# Altium schematic sheet c2020_schematic.SchDoc(c2020_schematic)
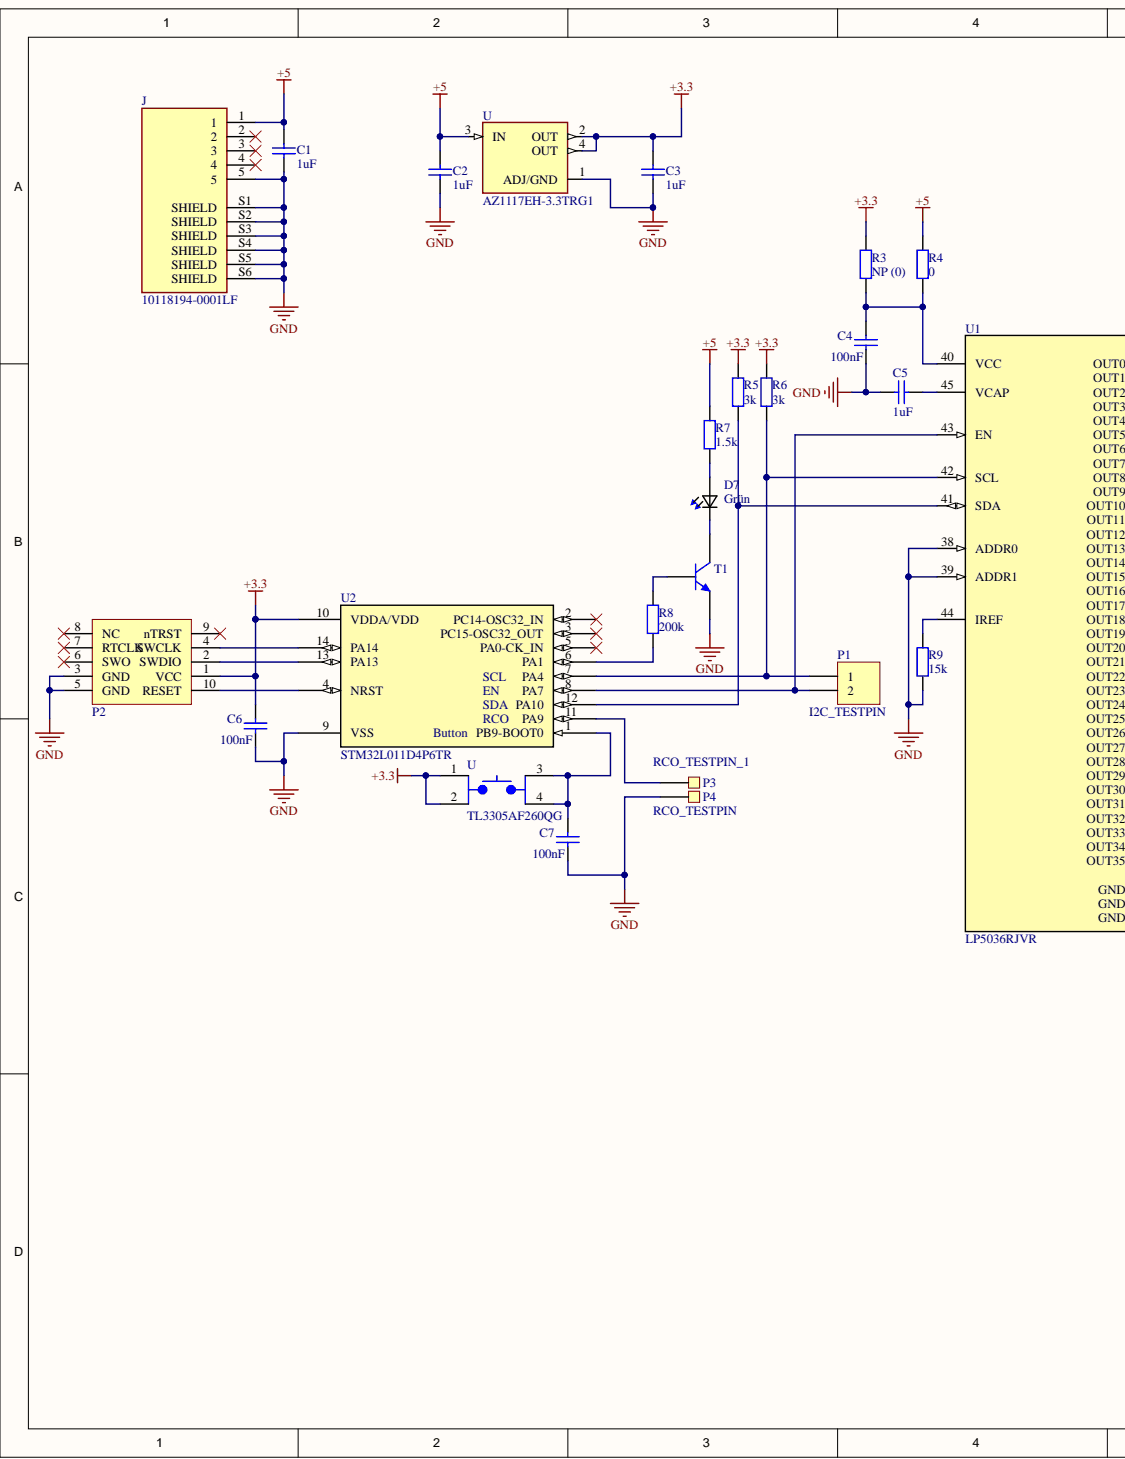
[diagram p0: c2020_schematic.SchDoc, left]
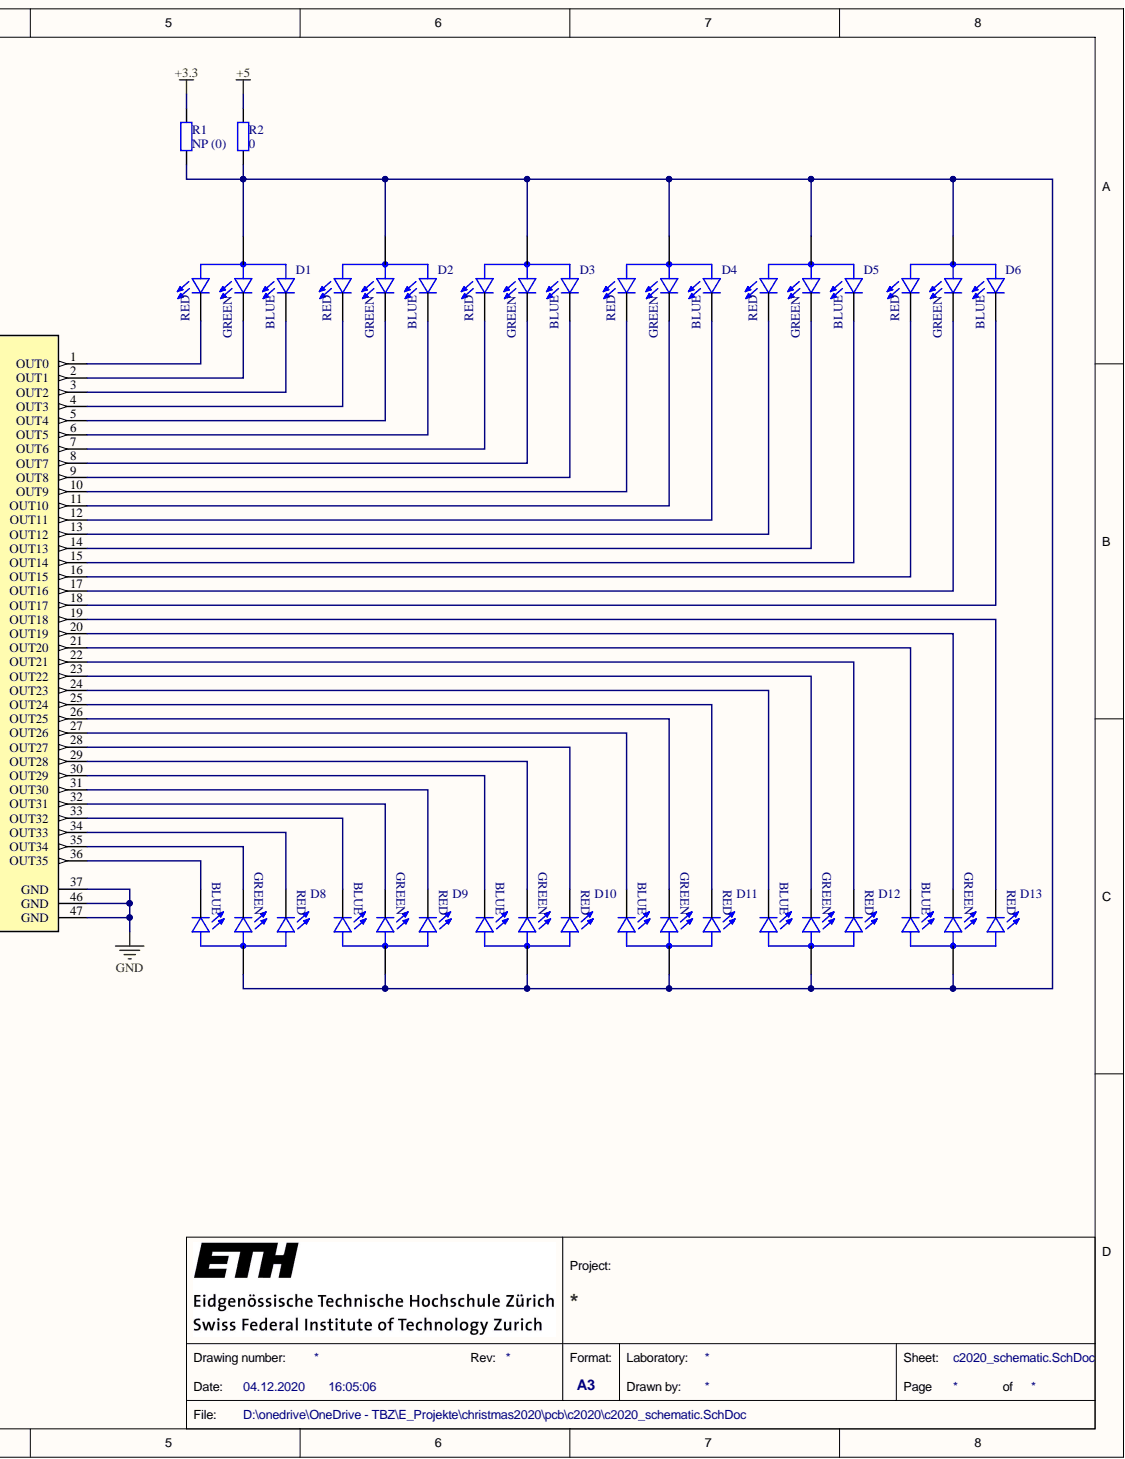
[diagram p1: c2020_schematic.SchDoc, right]

## Parts
C1  1uF  [CAP_0603i_1608m -N]
C2  1uF  [CAP_0603i_1608m -N]
C3  1uF  [CAP_0603i_1608m -N]
C4  100nF  [CAP_0402i_1005m -N]
C5  1uF  [CAP_0603i_1608m -N]
C6  100nF  [CAP_0402i_1005m -N]
C7  100nF  [CAP_0402i_1005m -N]
D1  156120M173000  [LED_156120M173000]  (value not drawn)
D2  156120M173000  [LED_156120M173000]  (value not drawn)
D3  156120M173000  [LED_156120M173000]  (value not drawn)
D4  156120M173000  [LED_156120M173000]  (value not drawn)
D5  156120M173000  [LED_156120M173000]  (value not drawn)
D6  156120M173000  [LED_156120M173000]  (value not drawn)
D7  Grün  [*0603]
D8  156120M173000  [LED_156120M173000]  (value not drawn)
D9  156120M173000  [LED_156120M173000]  (value not drawn)
D10  156120M173000  [LED_156120M173000]  (value not drawn)
D11  156120M173000  [LED_156120M173000]  (value not drawn)
D12  156120M173000  [LED_156120M173000]  (value not drawn)
D13  156120M173000  [LED_156120M173000]  (value not drawn)
J  10118194-0001LF  [AMPHENOL_10118194-0001LF]
P1  I2C_TESTPIN  [HDR1X2]
P2  Tag-Connect TC2050-IDC-NL-No pin assigned  [TAG-CONNECT TC2050-IDC-NL 10-Pin]  (value not drawn)
P3  RCO_TESTPIN_1  [Pad_0603]
P4  RCO_TESTPIN  [Pad_0603]
R1  NP (0)  [RES_0603i_1608m -N]
R2  0  [RES_0603i_1608m -N]
R3  NP (0)  [RES_0603i_1608m -N]
R4  0  [RES_0603i_1608m -N]
R5  3k  [RES_0402i_1005m -N]
R6  3k  [RES_0402i_1005m -N]
R7  1.5k  [RES_0402i_1005m -N]
R8  200k  [RES_0402i_1005m -N]
R9  15k  [RES_0402i_1005m -N]
T1  BJT NPN  [SOT23-N]  (value not drawn)
U  AZ1117EH-3.3TRG1  [SOT230P700X180-4N]
U  TL3305AF260QG  [SW_TL3305AF260QG]
U1  LP5036RJVR  [RJV0046A]
U2  STM32L011D4P6TR  [TSSOP14]

## Nets
+3.3: C3.2 C6.2 P2.1(VCC) R1.2 R3.2 R5.2 R6.2 U.1 U.2 U.4 U2.10(VDDA/VDD)
+5: C1.2 C2.2 J.1 R2.2 R4.2 R7.2 U.3
GND: C1.1 C2.1 C3.1 C4.1 C5.1 C6.1 C7.1 J.5 J.S1(SHIELD) J.S2(SHIELD) J.S3(SHIELD) J.S4(SHIELD) J.S5(SHIELD) J.S6(SHIELD) P2.3(GND) P2.5(GND) P4.1 R9.1 T1.2 U.1 U1.37(GND) U1.38(ADDR0) U1.39(ADDR1) U1.46(GND) U1.47(GND) U2.9(VSS)
NetC4_2: C4.2 R3.1 R4.1 U1.40(VCC)
NetC5_2: C5.2 U1.45(VCAP)
NetC7_2: C7.2 U.3 U.4 U2.1(PB9-BOOT0)
NetD1_1: D1.1 D2.1 D3.1 D4.1 D5.1 D6.1 D8.1 D9.1 D10.1 D11.1 D12.1 D13.1 R1.1 R2.1
NetD1_2: D1.2 U1.3(OUT2)
NetD1_3: D1.3 U1.1(OUT0)
NetD1_4: D1.4 U1.2(OUT1)
NetD2_2: D2.2 U1.6(OUT5)
NetD2_3: D2.3 U1.4(OUT3)
NetD2_4: D2.4 U1.5(OUT4)
NetD3_2: D3.2 U1.9(OUT8)
NetD3_3: D3.3 U1.7(OUT6)
NetD3_4: D3.4 U1.8(OUT7)
NetD4_2: D4.2 U1.12(OUT11)
NetD4_3: D4.3 U1.10(OUT9)
NetD4_4: D4.4 U1.11(OUT10)
NetD5_2: D5.2 U1.15(OUT14)
NetD5_3: D5.3 U1.13(OUT12)
NetD5_4: D5.4 U1.14(OUT13)
NetD6_2: D6.2 U1.18(OUT17)
NetD6_3: D6.3 U1.16(OUT15)
NetD6_4: D6.4 U1.17(OUT16)
NetD7_1: D7.1 T1.3
NetD7_2: D7.2 R7.1
NetD8_2: D8.2 U1.36(OUT35)
NetD8_3: D8.3 U1.34(OUT33)
NetD8_4: D8.4 U1.35(OUT34)
NetD9_2: D9.2 U1.33(OUT32)
NetD9_3: D9.3 U1.31(OUT30)
NetD9_4: D9.4 U1.32(OUT31)
NetD10_2: D10.2 U1.30(OUT29)
NetD10_3: D10.3 U1.28(OUT27)
NetD10_4: D10.4 U1.29(OUT28)
NetD11_2: D11.2 U1.27(OUT26)
NetD11_3: D11.3 U1.25(OUT24)
NetD11_4: D11.4 U1.26(OUT25)
NetD12_2: D12.2 U1.24(OUT23)
NetD12_3: D12.3 U1.22(OUT21)
NetD12_4: D12.4 U1.23(OUT22)
NetD13_2: D13.2 U1.21(OUT20)
NetD13_3: D13.3 U1.19(OUT18)
NetD13_4: D13.4 U1.20(OUT19)
NetP1_1: P1.1 R6.1 U1.42(SCL) U2.7(PA4)
NetP1_2: P1.2 U1.43(EN) U2.8(PA7)
NetP2_2: P2.2(SWDIO) U2.13(PA13)
NetP2_4: P2.4(SWCLK) U2.14(PA14)
NetP2_10: P2.10(RESET) U2.4(NRST)
NetP3_1: P3.1 U2.11(PA9)
NetR5_1: R5.1 U1.41(SDA) U2.12(PA10)
NetR8_1: R8.1 U2.6(PA1)
NetR8_2: R8.2 T1.1
NetR9_2: R9.2 U1.44(IREF)

Not connected: J 2 3 4; P2 6(SWO) 7(RTCLK) 8(NC) 9(nTRST); U2 2(PC14-OSC32_IN) 3(PC15-OSC32_OUT) 5(PA0-CK_IN)

## Library data of the parts
C1-C7 = Cap: capacitor; Simulation: CAPACITOR; Signal Integrity: Cap; Component Kind: Standard; Library Name: IDSC std generic parts.IntLib
D1-D6 D8-D13 = 156120M173000: Red, Green, Blue (RGB) 624nm Red, 525nm Green, 470nm Blue LED Indication - Discrete 2V Red, 3.3V Green, 3.3V Blue 1206 (3216 Metric); MF: Wurth Electronics; SnapEDA_Link: https://www.snapeda.com/parts/156120M173000/Wurth%20Electronics%20Inc./view-part/3623792/?ref=snap; Purchase-URL: https://pricing.snapeda.com/search/part/156120M173000/?ref=eda; Package: 1206 Wurth Electronics Inc.; MP: 156120M173000; Library Name: lib_c2020.IntLib; Component Kind: Standard; Check_prices: https://www.snapeda.com/parts/156120M173000/Wurth%20Electronics%20Inc./view-part/3623792/?ref=eda
D7 = LTST-C191KGKT: LED; SMD; 0603; grün; 35mcd; 1.6x0.8x0.55mm; 130Â°; 2Ã·2.V; 20mA; MF: Lite-On Inc.; SnapEDA_Link: https://www.snapeda.com/parts/LTST-C191KFKT/Lite-On%20Inc./view-part/?ref=snap; Package: 0603 Lite-On; MP: LTST-C191KGKT; Digi-Key_Purchase_URL: https://www.digikey.ch/product-detail/de/lite-on-inc/LTST-C191KGKT/160-1446-1-ND/386834; Digi-Key_Part_Number: 160-1446-1-ND; Component Kind: Standard; Library Name: Funk.IntLib
J = 10118194-0001LF: USB - micro B USB 2.0 Receptacle Connector 5 Position Surface Mount, Right Angle; Through Hole; MF: Amphenol ICC; SnapEDA_Link: https://www.snapeda.com/parts/10118194-0001LF/Amphenol%20FCI/view-part/1413308/?ref=snap; Price: None; Package: None; MP: 10118194-0001LF; Library Name: lib_c2020.IntLib; Component Kind: Standard; Availability: Unavailable
P1 = Header 2: Header, 2-Pin; Signal Integrity: Connector; Component Kind: Standard; Library Name: IDSC std connectors.IntLib
P2 = Tag-Connect TC2050-IDC-NL-No pin assigned: 10-pin pogo pin programing port; Component Kind: Standard; Library Name: IDSC std connectors.IntLib
P3 P4 = Pad: Single Pad or Testpoint; Signal Integrity: Connector; Component Kind: Standard; Library Name: IDSC std connectors.IntLib
R1-R9 = Res: Resistor; Simulation: RESISTOR; Signal Integrity: Res; Component Kind: Standard; Library Name: IDSC std generic parts.IntLib
T1 = BJT NPN: NPN Bipolar Transistor; Note: Check footprint as it may  differ; Simulation: NPN; Signal Integrity: NPN; Component Kind: Standard; Library Name: IDSC std generic parts.IntLib
U = AZ1117EH-3.3TRG1: Linear Voltage Regulator IC 1 Output 1A SOT-223; MF: Diodes Inc.; SnapEDA_Link: https://www.snapeda.com/parts/AZ1117EH-3.3TRG1/Diodes%20Inc./view-part/482930/?ref=snap; Price: None; Package: SOT-223 Diodes Inc.; MP: AZ1117EH-3.3TRG1; Library Name: lib_c2020.IntLib; Component Kind: Standard; Availability: Unavailable
U = TL3305AF260QG: Tactile Switch SPST-NO Top Actuated Surface Mount; MF: E-Switch; SnapEDA_Link: https://www.snapeda.com/parts/TL3305AF260QG/E-Switch/view-part/479903/?ref=snap; Price: None; Package: LQFP-48 E-Switch; MP: TL3305AF260QG; Library Name: lib_c2020.IntLib; Component Kind: Standard; Availability: Unavailable
U1 = LP5036RJVR: No Description Available; Manufacturer: Texas Instruments; Value: Value; Type: DEV; RefDes: RefDes; PartNumber: LP5036RJVR; Library Name: lib_c2020.IntLib; Component Kind: Standard; Copyright: Copyright (C) 2018 Accelerated Designs. All rights reserved
U2 = STM32L011D4P6TR: No Description Available; Vendor: STMicroelectronics; SourceLibrary: STMicroelectronics_2020-10-07; Built_By: EMA_UL_Team; Type: DEV; RefDes: RefDes; Manufacturer_Part_Number: STM32L011D4P6TR; Library Name: lib_c2020.IntLib; Component Kind: Standard; Copyright: Copyright (C) 2018 Accelerated Designs. All rights reserved
